# Altium schematic sheet AVR.SchDoc(AVR)
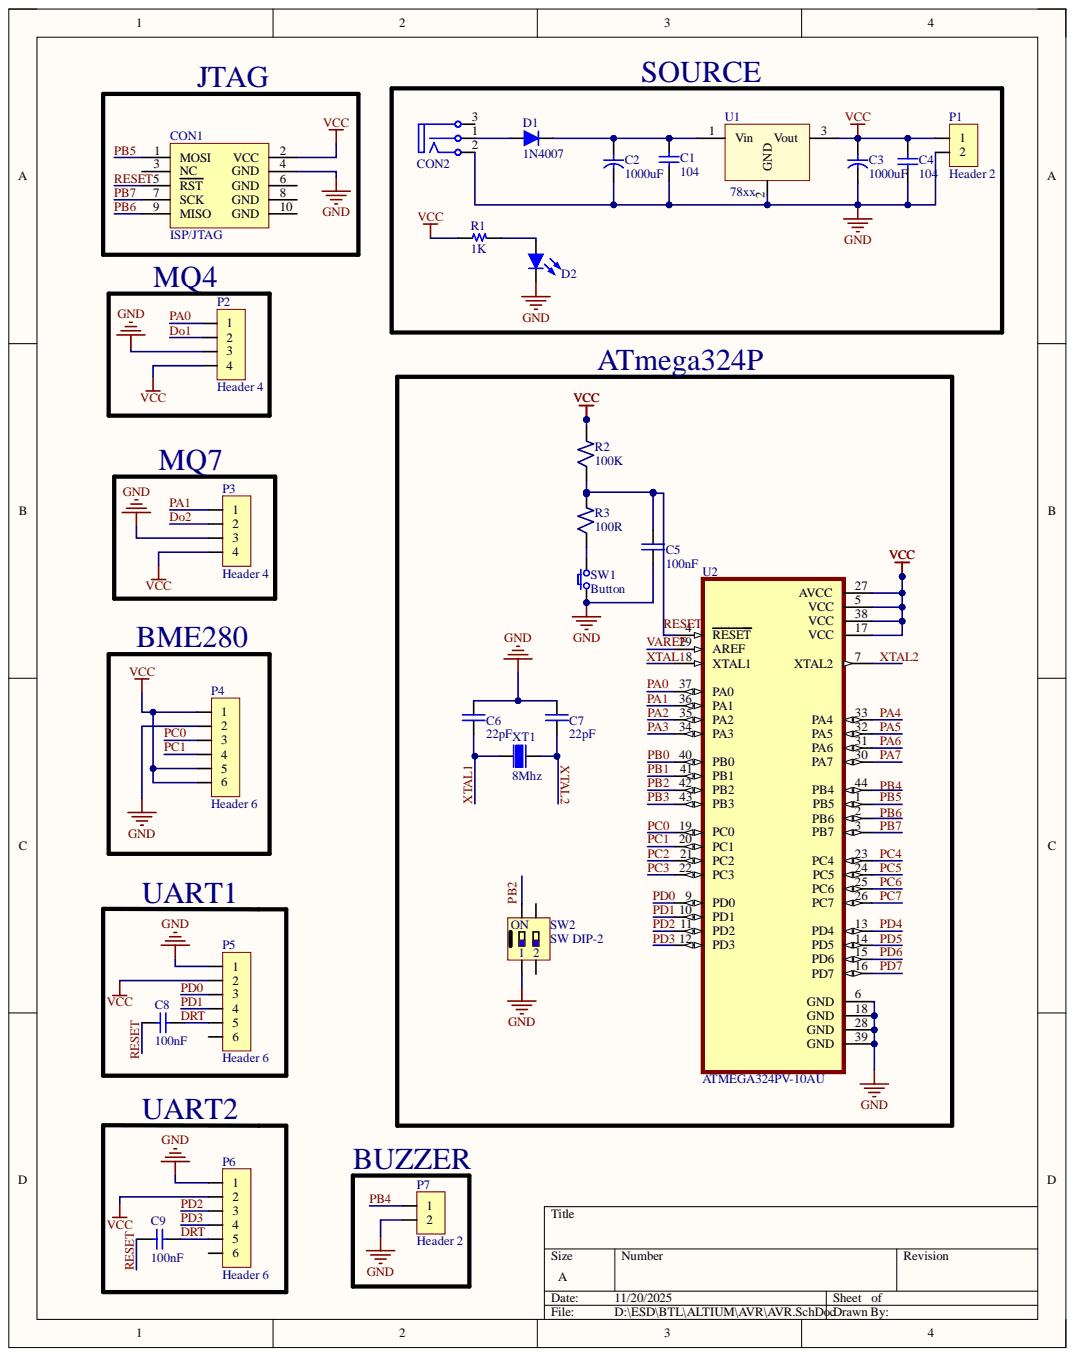
[diagram p0: AVR.SchDoc]

## Parts
C1  104  [CAP Ceramic/W300]
C2  1000uF  [CYL/D.400/LS.200]
C3  1000uF  [CYL/D.400/LS.200]
C4  104  [CAP Ceramic/W300]
C5  value 100nF  [C0805]  (hidden comment: Cap Non-Pol)
C6  value 22pF  [C0805]  (hidden comment: Cap Non-Pol)
C7  value 22pF  [C0805]  (hidden comment: Cap Non-Pol)
C8  100nF  [C 0805]
C9  100nF  [C 0805]
CON1  ISP/JTAG  [HDR/Header/10/DL/BOX]
CON2  CON DC PWR  [CONN/DC/PWR/5.0MM]  (value not drawn)
D1  1N4007  [DO-41]
D2  Led  [Led 0805 RED]  (value not drawn)
P1  Header 2  [HDR/Terminal/2]
P2  Header 4  [HDR/Header/4]
P3  Header 4  [HDR/Header/4]
P4  Header 6  [HDR/Header/6]
P5  Header 6  [HDR/Header/6]
P6  Header 6  [HDR/Header/6]
P7  Header 2  [HDR/Header/2]
R1  1K  [R 0805]
R2  value 100K  [R0805]  (hidden comment: Resistor)
R3  value 100R  [R0805]  (hidden comment: Resistor)
SW1  Button  [SW/2/DIP]
SW2  SW DIP-2  [SW/DIP2]
U1  78xx  [TO-220]
U2  ATMEGA324PV-10AU  [QFP80P1200X1200X120-44N]
XT1  XTAL  Frequency: 8Mhz  [XT2]

## Nets
GND: C1.2 C2.2 C3.2 C4.2 C5.1 C6.1 C7.1 CON1.4(GND) CON2.2 D2.2 P1.2 P2.3 P3.3 P4.2 P5.1 P6.1 P7.2 SW1.1 SW2.1 U1.2 U2.6(GND) U2.18(GND) U2.28(GND) U2.39(GND)
VCC: C3.1 C4.1 CON1.2(VCC) P1.1 P2.4 P3.4 P4.1 P4.5 P4.6 P5.2 P6.2 R1.1 R2.2 U1.3 U2.5(VCC) U2.17(VCC) U2.27(AVCC) U2.38(VCC)
Do1: P2.2
Do2: P3.2
DRT: C9.2 P6.5
PA0: P2.1 U2.37(PA0)
PA1: P3.1 U2.36(PA1)
PA2: U2.35(PA2)
PA3: U2.34(PA3)
PA4: U2.33(PA4)
PA5: U2.32(PA5)
PA6: U2.31(PA6)
PA7: U2.30(PA7)
PB0: U2.40(PB0)
PB1: U2.41(PB1)
PB2: U2.42(PB2)
PB3: U2.43(PB3)
PB4: P7.1
PB5: CON1.1(MOSI) U2.1(PB5)
PB6: CON1.9(MISO)
PB7: CON1.7(SCK) U2.3(PB7)
PC0: P4.3 U2.19(PC0)
PC1: P4.4 U2.20(PC1)
PC2: U2.21(PC2)
PC3: U2.22(PC3)
PC4: U2.23(PC4)
PC5: U2.24(PC5)
PC6: U2.25(PC6)
PC7: U2.26(PC7)
PD0: P5.3 U2.9(PD0)
PD1: P5.4 U2.10(PD1)
PD2: P6.3 U2.11(PD2)
PD3: P6.4 U2.12(PD3)
PD4: U2.13(PD4)
PD5: U2.14(PD5)
PD6: U2.15(PD6)
PD7: U2.16(PD7)
RESET: C5.2 C9.1 CON1.5(RST) R2.1 R3.2 U2.4(RESET)
VAREF: U2.29(AREF)
XTAL1: C6.2 U2.8(XTAL1) XT1.2
XTAL2: C7.2 U2.7(XTAL2) XT1.1
NetC1_1: C1.1 C2.1 D1.2 U1.1
NetC8_2: C8.2 P5.5
NetCON2_1: CON2.1 D1.1
NetD2_1: D2.1 R1.2
NetR3_1: R3.1 SW1.2

Not connected: C8 1; CON1 3(NC) 6(GND) 8(GND) 10(GND); CON2 3; P5 6; P6 6; SW2 2 3 4(ON); U2 2(PB6) 44(PB4)

## Library data of the parts
C1 C4 C8 C9 = Capacitor NP: Capacitor non-pol; Component Kind: Standard; Library Name: chipfc_libs.SchLib
C2 C3 = Capacitor: Capacitor pol; Component Kind: Standard; Library Name: chipfc_libs.SchLib
C5-C7 = Cap Non-Pol: Non-Polarized Capacitor; Component Kind: Standard; Library Name: STM32F4_Board.IntLib
CON1 = CON ISP AVR 10-pin: ISP AVR 10-pin interface; Component Kind: Standard; Library Name: connectors.SchLib
CON2 = CON DC PWR: DC input connector; Component Kind: Standard; Library Name: connectors.SchLib
D1 = Diode: Default Diode; Component Kind: Standard; Library Name: chipfc_libs.SchLib
D2 = Led: Typical LED; Component Kind: Standard; Library Name: led.SchLib
P1 P7 = Header 2: Header, 2-Pin; Component Kind: Standard; Library Name: connectors.SchLib
P2 P3 = Header 4: Header, 4-Pin; Component Kind: Standard; Library Name: connectors.SchLib
P4-P6 = Header 6: Header, 6-Pin; Component Kind: Standard; Library Name: connectors.SchLib
R1 = Resistor: Component Kind: Standard; Description: Resistor; Library Name: chipfc_libs.SchLib
SW1 = SW SPST: Tact switch 2 pin; Component Kind: Standard; Library Name: chipfc_libs.SchLib
U1 = 78xx: LDO Fixed Voltage regulator; Component Kind: Standard; Library Name: chipfc_libs.SchLib
U2 = ATMEGA324PV-10AU: AVR AVRÂ® ATmega Microcontroller IC 8-Bit 10MHz 32KB (16K x 16) FLASH 44-TQFP (10x10); MF: Microchip; Check_prices: https://www.snapeda.com/parts/ATMEGA324PV-10AU/Microchip+Technology/view-part/?ref=eda; Component Kind: Standard; Library Name: ATMEGA324PV-10AU.IntLib; MP: ATMEGA324PV-10AU; Package: TQFP-44 Microchip; SnapEDA_Link: https://www.snapeda.com/parts/ATMEGA324PV-10AU/Microchip+Technology/view-part/?ref=snap
XT1 = XTAL: Crystal; Component Kind: Standard; Library Name: STM32F4_Board.IntLib
R2 R3 = Resistor: Component Kind: Standard; Description: Resistor; Library Name: STM32F4_Board.IntLib
SW2 = SW DIP-2: Component Kind: Standard; Library Name: chipfc_libs.SchLib
